# Altium schematic sheet FPGA_CFG.SchDoc(FPGA_CFG)
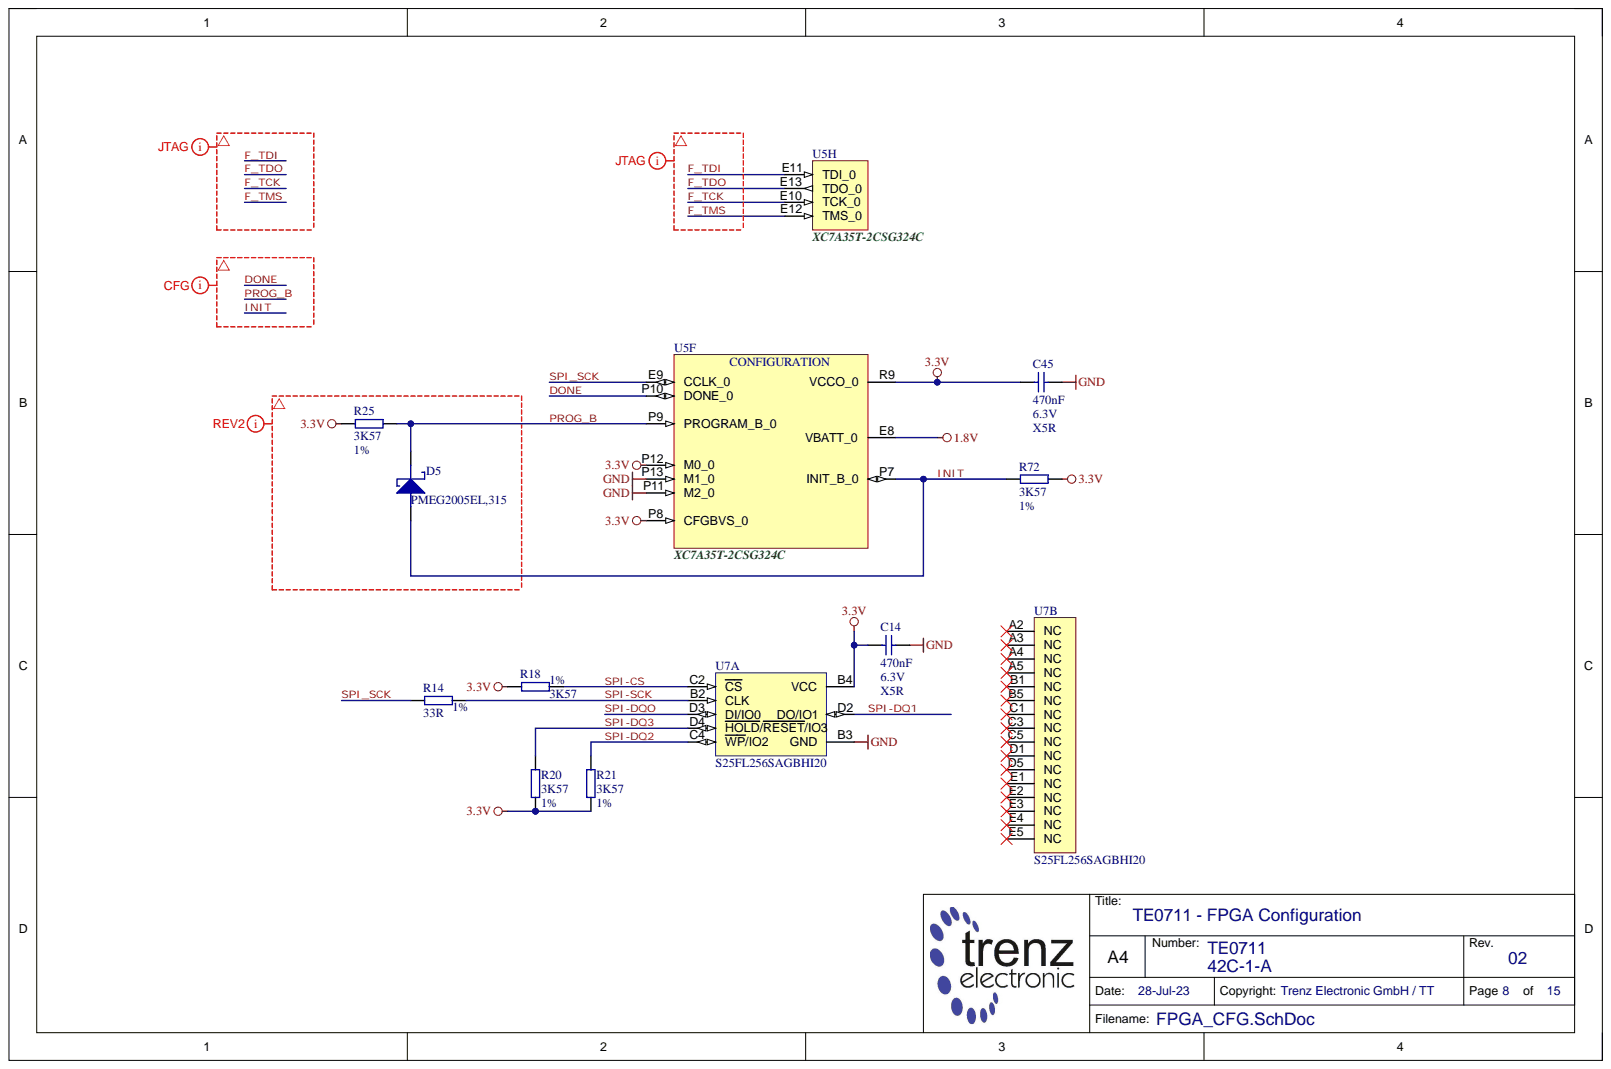
[diagram p0: FPGA_CFG.SchDoc]

## Parts
C14  470nF  Voltage: 6.3V  [CAPC0603X33N_V]
C45  470nF  Voltage: 6.3V  [CAPC0603X33N_V]
D5  PMEG2005EL,315  [DIODFN98X58X50-2N]
R14  33R  Tolerance: 1%  [RESC1005X40N_V]
R18  3K57  Tolerance: 1%  [RESC1005X40N_V]
R20  3K57  Tolerance: 1%  [RESC1005X40N_V]
R21  3K57  Tolerance: 1%  [RESC1005X40N_V]
R25  3K57  Tolerance: 1%  [RESC1005X40N_V]
R72  3K57  Tolerance: 1%  [RESC1005X40N_V]
U5  XC7A35T-2CSG324C  [BGA324C80P18X18_1500X1500X150N]  (parts F,H)
U5
U5
U5
U5
U5
U5
U7  S25FL256SAGBHI20  [BGA24C100P5X5_800X600X120N]  (parts A,B)

## Nets
1.8V: U5.E8
3.3V: C14.1 C45.2 R18.1 R20.2 R21.1 R25.2 R72.2 U5.P8 U5.P12 U5.R9 U7.B4(VCC)
GND: C14.2 C45.1 U5.P11 U5.P13 U7.B3(GND)
DONE: U5.P10
F_TCK: U5.E10
F_TDI: U5.E11
F_TDO: U5.E13
F_TMS: U5.E12
INIT: D5.2 R72.1 U5.P7
PROG_B: D5.1 R25.1 U5.P9
SPI-CS: R18.2 U7.C2(CS)
SPI-DQ1: U7.D2(DO/IO1)
SPI-DQ2: R21.2 U7.C4(WP/IO2)
SPI-DQ3: R20.1 U7.D4(HOLD/RESET/IO3)
SPI-DQO: U7.D3(DI/IO0)
SPI-SCK: R14.2 U7.B2(CLK)
SPI_SCK: R14.1 U5.E9

Not connected: U7 A2(NC) A3(NC) A4(NC) A5(NC) B1(NC) B5(NC) C1(NC) C3(NC) C5(NC) D1(NC) D5(NC) E1(NC) E2(NC) E3(NC) E4(NC) E5(NC)

## Library data of the parts
C14 C45 = 24921: KON 470nF 6.3V X5R 20%  SMD BF 0603 (0201); Database Table Name: C_0603_0201; Mixed: SMT; Preferred: Yes; Temperature-Range: -55/+85; Value: 470n; AN: 24921; Case: 0603 (0201); Component Kind: Standard; Library Name: TE.DbLib; Technology: X5R; Tolerance: 20%
D5 = 29676: Schottky Diode 0.5A 20V DFN1006-2; Extra: Small package; Mixed: SMT; Temperature-Range: -65/+150; AN: 29676; Case: SOD882 / DFN1006-2; Component Kind: Standard; Database Table Name: DIODE; H-ART: PMEG2005EL,315; Library Name: TE.DbLib; Storage-Temperature: -65/+150(Abs); Technology: Schottky; Value: 0.5A; Voltage: 20V; HelpURL: b:\AD\adlib\datasheet\PMEG2005EL\
R14 = 22770: WID SMD 33R 1% 0,063W BF 1005 (0402); Database Table Name: R_1005_0402; H-ART: RC0402FR-0733RL; Mixed: SMT; Preferred: Yes; Value: 33; Voltage: 0.063W; AN: 22770; Case: 1005 (0402); Component Kind: Standard; Library Name: TE.DbLib; Technology: TK100
R18 R20 R21 R25 R72 = 23145: WID SMD 3K57 1% 0,063W  BF 1005 (0402); Database Table Name: R_1005_0402; Mixed: SMT; Value: 3.57K; Voltage: 0.063W; AN: 23145; Case: 1005 (0402); Component Kind: Standard; Library Name: TE.DbLib; Technology: TK100
U5 = 24908: AN: 25960; Database Table Name: PROG_LOGIC; Extra: Xilinx Artix-7 FPGA 100K; H-ART: XC7A100T-2CSG324C; Mixed: SMT; Trenz-Stock: Yes; Case: CSG324; Component Kind: Standard; Description: XC7A35T-2CSG324C; Library Name: TE.DbLib; HelpURL: B:\AD\adlib\datasheet\XC7
U7 = 25945: Database Table Name: FLASH; Extra: 3V 256M-Bit Serial Flash Memory 5x5 BGA; Mixed: SMT; Preferred: Yes; Temperature-Range: -40/+85; AN: 25945; Case: TFBGA24; Component Kind: Standard; Description: S25FL256SAGBHI20; H-ART: S25FL256SAGBHI20; Library Name: TE.DbLib; Storage-Temperature: -65/+150 (Abs); Trenz-Stock: Yes; HelpURL: B:\AD\adlib\datasheet\S25FL256SAGNFI00
